# Altium schematic sheet IOS.SchDoc
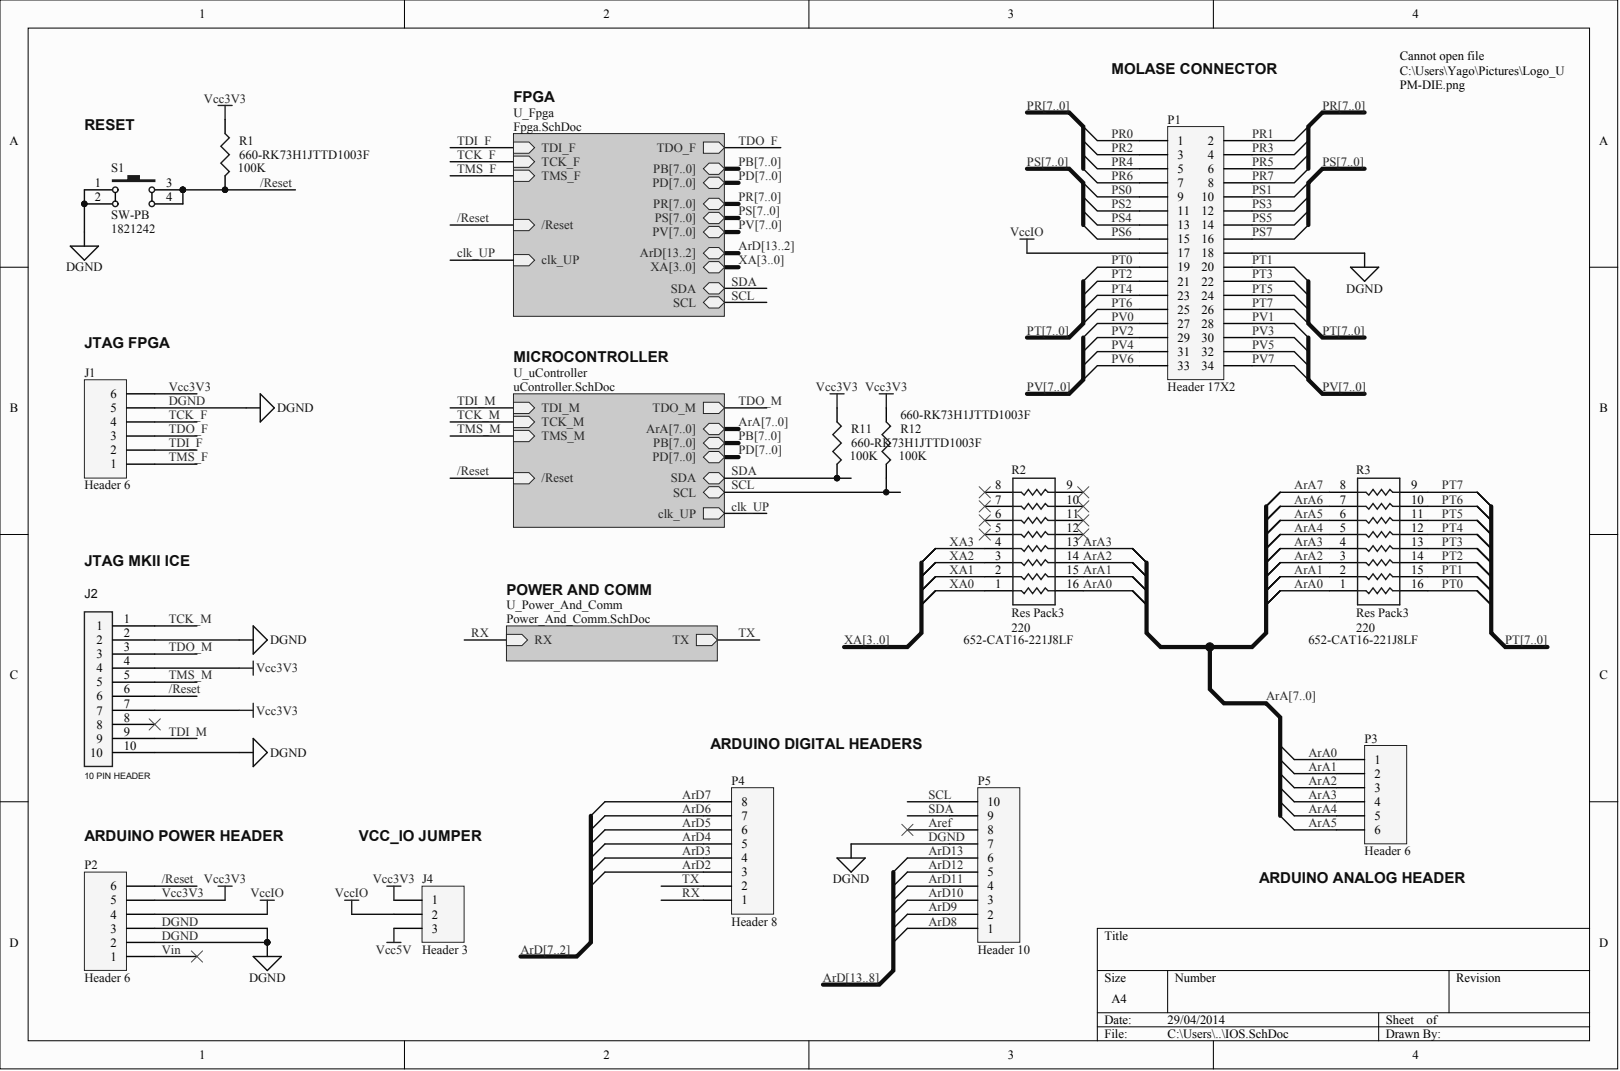
[diagram p0: IOS.SchDoc]

## Parts
J1  Header 6
J2  10 PIN HEADER
J4  Header 3
Logo-UPM-DIE_1  Logo UPM-DIE
P1  Header 17X2
P2  Header 6
P3  Header 6
P4  Header 8
P5  Header 10
R1  value 100K  Supplier Part Number 1: 660-RK73H1JTTD1003F  (hidden comment: Res3)
R2  Res Pack3  value 220
R3  Res Pack3  value 220
R11  value 100K  Supplier Part Number 1: 660-RK73H1JTTD1003F  (hidden comment: Res3)
R12  value 100K  Supplier Part Number 1: 660-RK73H1JTTD1003F  (hidden comment: Res3)
S1  SW-PB  Supplier Part Number 1: 1821242

## Nets
VCC5V: J4.3
VCCIO: J4.2 P1.17 P2.4
/RESET: J2.6 P2.6 R1.2 S1.3 S1.4
ARA0: P3.1 R2.16 R3.1  [also labelled ARA]
ARA1: P3.2 R2.15 R3.2  [also labelled ARA]
ARA2: P3.3 R2.14 R3.3  [also labelled ARA]
ARA3: P3.4 R2.13 R3.4  [also labelled ARA]
ARA4: P3.5 R3.5  [also labelled ARA]
ARA5: P3.6 R3.6  [also labelled ARA]
ARA6: R3.7  [also labelled ARA]
ARA7: R3.8  [also labelled ARA]
ARD2: P4.3  [also labelled ARD]
ARD3: P4.4  [also labelled ARD]
ARD4: P4.5  [also labelled ARD]
ARD5: P4.6  [also labelled ARD]
ARD6: P4.7  [also labelled ARD]
ARD7: P4.8  [also labelled ARD]
ARD8: P5.1  [also labelled ARD]
ARD9: P5.2  [also labelled ARD]
ARD10: P5.3  [also labelled ARD]
ARD11: P5.4  [also labelled ARD]
ARD12: P5.5  [also labelled ARD]
ARD13: P5.6  [also labelled ARD]
AREF: P5.8
DGND: J1.5 J2.2 J2.10 P1.18 P2.2 P2.3 P5.7 S1.1 S1.2
PR0: P1.1  [also labelled PR]
PR1: P1.2  [also labelled PR]
PR2: P1.3  [also labelled PR]
PR3: P1.4  [also labelled PR]
PR4: P1.5  [also labelled PR]
PR5: P1.6  [also labelled PR]
PR6: P1.7  [also labelled PR]
PR7: P1.8  [also labelled PR]
PS0: P1.9  [also labelled PS]
PS1: P1.10  [also labelled PS]
PS2: P1.11  [also labelled PS]
PS3: P1.12  [also labelled PS]
PS4: P1.13  [also labelled PS]
PS5: P1.14  [also labelled PS]
PS6: P1.15  [also labelled PS]
PS7: P1.16  [also labelled PS]
PT0: P1.19 R3.16  [also labelled PT]
PT1: P1.20 R3.15  [also labelled PT]
PT2: P1.21 R3.14  [also labelled PT]
PT3: P1.22 R3.13  [also labelled PT]
PT4: P1.23 R3.12  [also labelled PT]
PT5: P1.24 R3.11  [also labelled PT]
PT6: P1.25 R3.10  [also labelled PT]
PT7: P1.26 R3.9  [also labelled PT]
PV0: P1.27  [also labelled PV]
PV1: P1.28  [also labelled PV]
PV2: P1.29  [also labelled PV]
PV3: P1.30  [also labelled PV]
PV4: P1.31  [also labelled PV]
PV5: P1.32  [also labelled PV]
PV6: P1.33  [also labelled PV]
PV7: P1.34  [also labelled PV]
RX: P4.1
SCL: P5.10 R12.2
SDA: P5.9 R11.2
TCK_F: J1.4
TCK_M: J2.1
TDI_F: J1.2
TDI_M: J2.9
TDO_F: J1.3
TDO_M: J2.3
TMS_F: J1.1
TMS_M: J2.5
TX: P4.2
VCC3V3: J1.6 J2.4 J2.7 J4.1 P2.5 R1.1 R11.1 R12.1
VIN: P2.1
XA0: R2.1  [also labelled XA]
XA1: R2.2  [also labelled XA]
XA2: R2.3  [also labelled XA]
XA3: R2.4  [also labelled XA]
NetJ2_8: J2.8
NetR2_5: R2.5
NetR2_6: R2.6
NetR2_7: R2.7
NetR2_8: R2.8
NetR2_9: R2.9
NetR2_10: R2.10
NetR2_11: R2.11
NetR2_12: R2.12
CLK_UP:
PB0:  [also labelled PB]
PB1:  [also labelled PB]
PB2:  [also labelled PB]
PB3:  [also labelled PB]
PB4:  [also labelled PB]
PB5:  [also labelled PB]
PB6:  [also labelled PB]
PB7:  [also labelled PB]
PD0:  [also labelled PD]
PD1:  [also labelled PD]
PD2:  [also labelled PD]
PD3:  [also labelled PD]
PD4:  [also labelled PD]
PD5:  [also labelled PD]
PD6:  [also labelled PD]
PD7:  [also labelled PD]

## Library data of the parts
R11 = (no symbol name): Value: 100K; Published: 8-Jun-2000; LatestRevisionDate: 29-May-2009; LatestRevisionNote: IPC-7351 Footprint Added.; PackageReference: J1-0603; PackageDescription: Chip Resistor; Supplier 1: Mouser; Publisher: Altium Limited
J1 J2 J4 Logo-UPM-DIE_1 P1-P5 R1-R3 R12 S1 = (no symbol name)
  J1 J4 P5: LatestRevisionDate: 17-Jul-2002; LatestRevisionNote: Re-released for DXP Platform.
  P1: LatestRevisionDate: 17-Jul-2002; LatestRevisionNote: Re-released for DXP Platform.; Supplier 1: Farnell
  P2: LatestRevisionDate: 17-Jul-2002; LatestRevisionNote: Re-released for DXP Platform.; FarnellPartNumber: 1531938
  P3 P4: LatestRevisionDate: 17-Jul-2002; LatestRevisionNote: Re-released for DXP Platform.; FarnellPartNumber: *
  R1 R12: Published: 8-Jun-2000; LatestRevisionDate: 29-May-2009; LatestRevisionNote: IPC-7351 Footprint Added.; PackageReference: J1-0603; PackageDescription: Chip Resistor; Supplier 1: Mouser
  R2 R3: Published: 24-Mar-1999; LatestRevisionDate: 29-May-2009; LatestRevisionNote: IPC-7351 Footprint Added.; PackageReference: SO-16; PackageDescription: 16-Pin Small Outline Integrated Circuit 1.27 mm Pitch; Code_JEDEC: MS-012-AC; Code_IPC: SOIC127P600-16; Supplier 1: Mouser; Supplier Part Number 1: 652-CAT16-221J8LF
  S1: Published: 24-Mar-1999; LatestRevisionDate: 17-Jul-2002; LatestRevisionNote: Re-released for DXP Platform.; PackageReference: SPST-2; PackageDescription: Switch; 2 Leads; Supplier 1: Farnell
  J1 J4 P1-P5 R1-R3 R12 S1: Publisher: Altium Limited
